# Altium schematic sheet gps.SchDoc(gps)
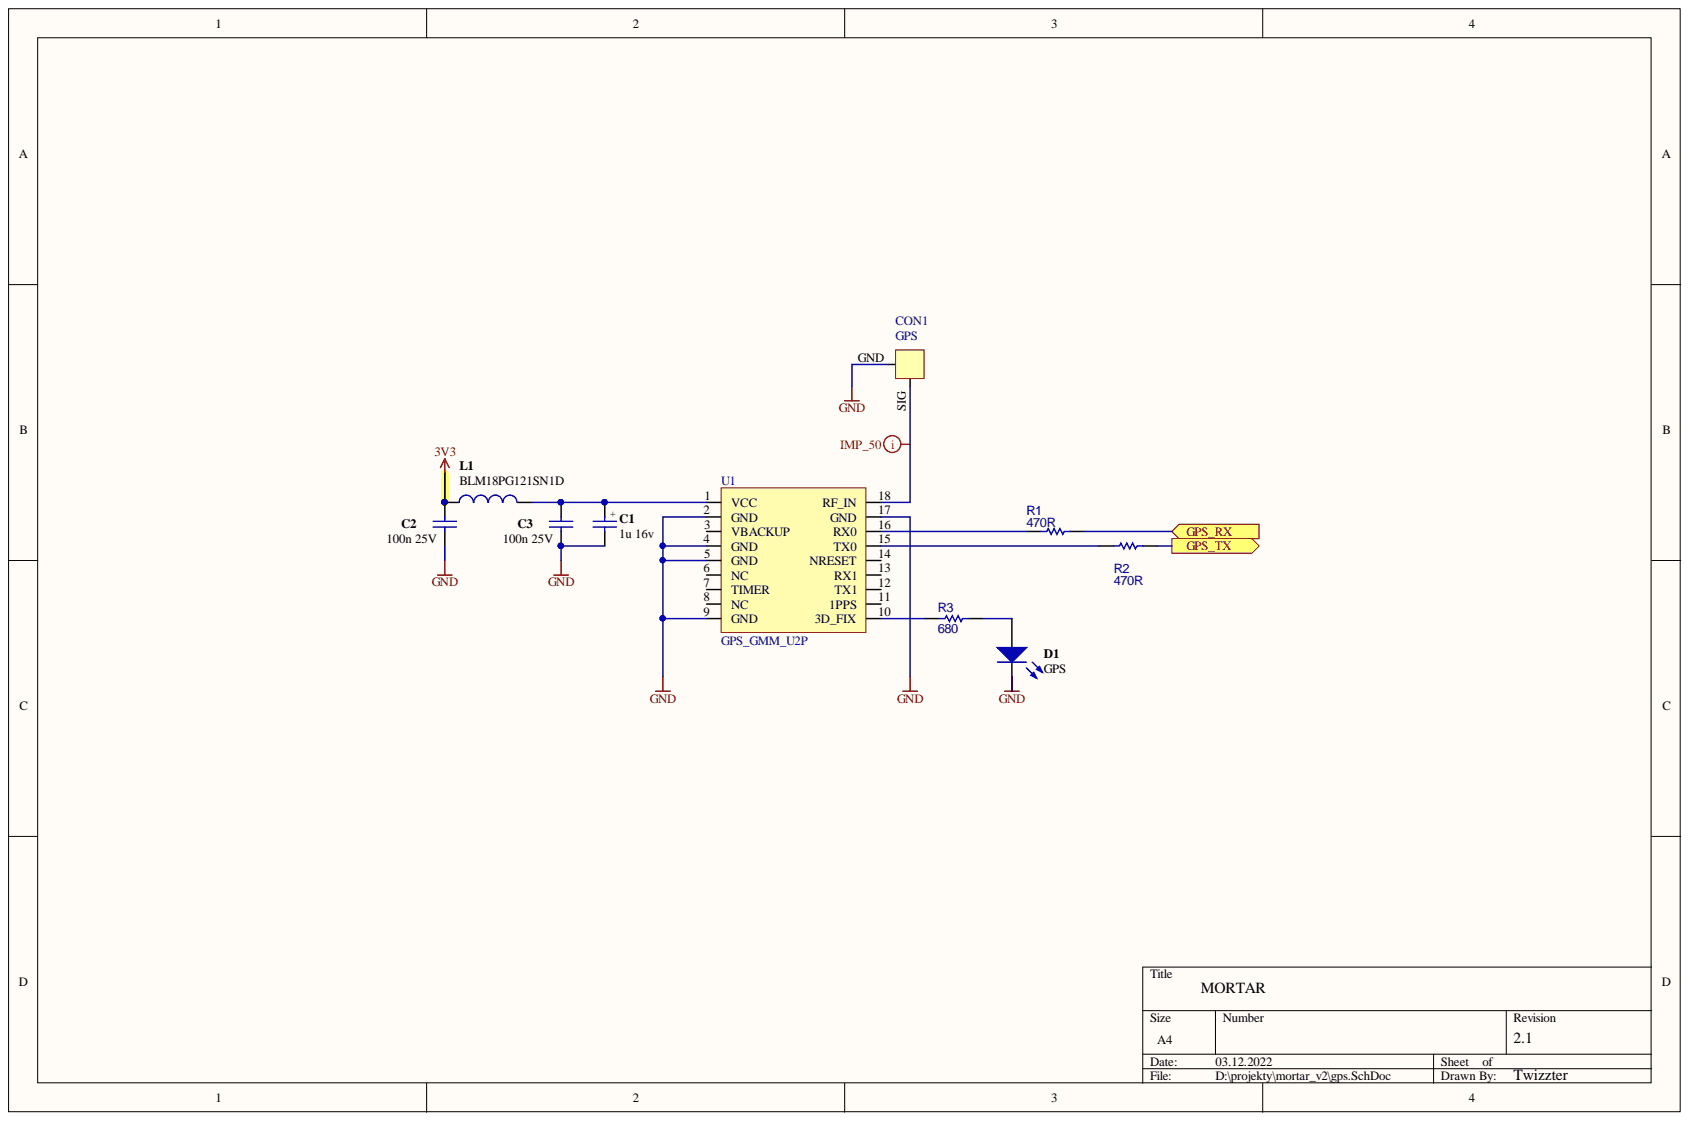
[diagram p0: gps.SchDoc]

## Parts
C1  value 1u 16v  [C_0603]  (hidden comment: C_1u_16V)
C2  value 100n 25V  [C_0603]  (hidden comment: C_100n_25V_0603)
C3  value 100n 25V  [C_0603]  (hidden comment: C_100n_25V_0603)
CON1  GPS  [uFL SMT]
D1  GPS  [D_0805]
L1  BLM18PG121SN1D  [R_0805]
R1  VALUE_TXT: 470R  [R_0603]  (hidden comment: R330R)
R2  R330R  [R_0603]  (value not drawn)
R3  R680R  [R_0603]  (value not drawn)
U1  GPS_GMM_U2P  [GPS_GMM_U2P]

## Ports
GPS_RX, GPS_TX

## Nets
3V3: C2.2 L1.2
GND: C1.1 C2.1 C3.1 CON1.GND D1.2 U1.2(GND) U1.4(GND) U1.5(GND) U1.9(GND) U1.17(GND)
GPS_RX: R1.2 ; port GPS_RX
GPS_TX: R2.2 ; port GPS_TX
NetC1_2: C1.2 C3.2 L1.1 U1.1(VCC)
NetCON1_SIG: CON1.SIG U1.18(RF_IN)
NetD1_1: D1.1 R3.2
NetR1_1: R1.1 U1.16(RX0)
NetR2_1: R2.1 U1.15(TX0)
NetR3_1: R3.1 U1.10(3D_FIX)

Not connected: U1 3(VBACKUP) 6(NC) 7(TIMER) 8(NC) 11(1PPS) 12(TX1) 13(RX1) 14(NRESET)

## Library data of the parts
C1 = C_1u_16V: mfg_pn: EMK107B7105KA-T; Library Name: SCH.SchLib; Component Kind: Standard
C2 C3 = C_100n_25V_0603: 100n 25V 0603 X7R; mfg_pn: 885012206071; Component Kind: Standard; Library Name: Kayon_01.SCHLIB
CON1 = UFL: Library Name: SCH.SchLib; Component Kind: Standard
D1 = LED_0805: mfg_pn: LL-S170VC-V1-1B; Library Name: SCH.SchLib; Description: GPS; Component Kind: Standard
L1 = L_0603: PackageVersion: 2009; PackageReference: SMD-0603; Library Name: SCH.SchLib; Description: BLM18PG121SN1D; Component Kind: Standard
R1 = R330R: SMD RES 330R-1%-1/10W; OPL_PART_NO: 301010300; MPN: RC0603FR-07330RL; Manufacturer: YAGEO; Package: 0603; Library Name: SCH.SchLib; Component Kind: Standard
R2 = R330R: SMD RES 330R-1%-1/10W; OPL_PART_NO: 301010300; MPN: RC0603FR-07330RL; Manufacturer: YAGEO; VALUE_TXT: 470R; Package: 0603; Library Name: SCH.SchLib; Component Kind: Standard
R3 = R680R: SMD RES 680R-1%-1/10W;0603; OPL_PART_NO: 301012092; MPN: RC0603FR-07680RL; Manufacturer: YAGEO; VALUE_TXT: 680; Package: 0603; Library Name: SCH.SchLib; Component Kind: Standard
U1 = GPS_GMM_U2P: Library Name: SCH.SchLib; Component Kind: Standard
